# Altium schematic sheet Decawave.schdoc(Decawave)
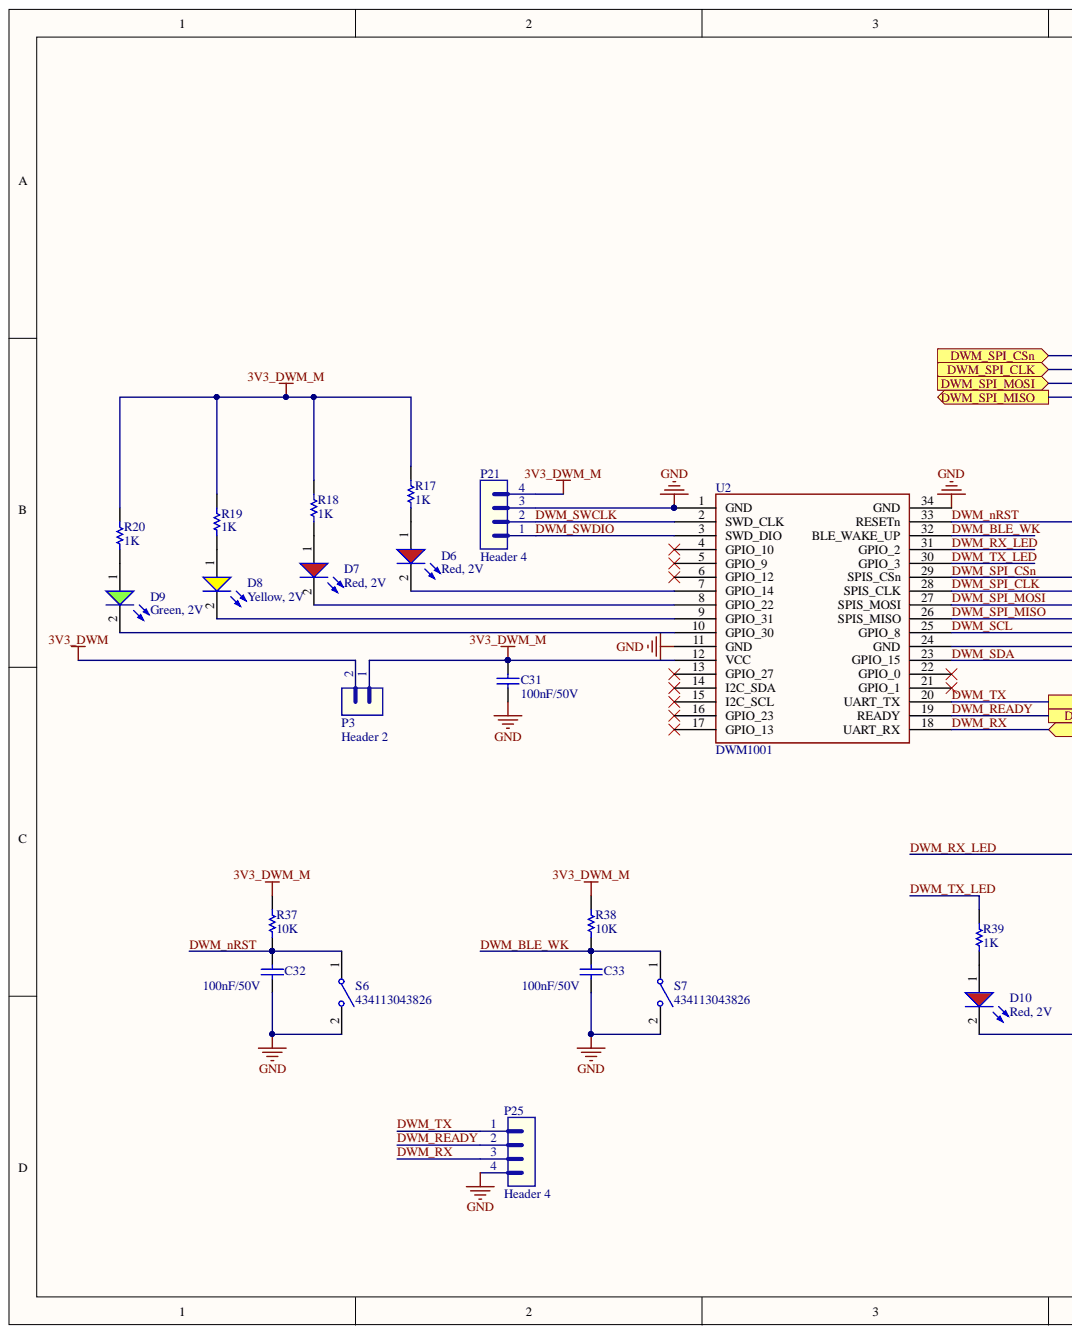
[diagram p0: Decawave.schdoc, left]
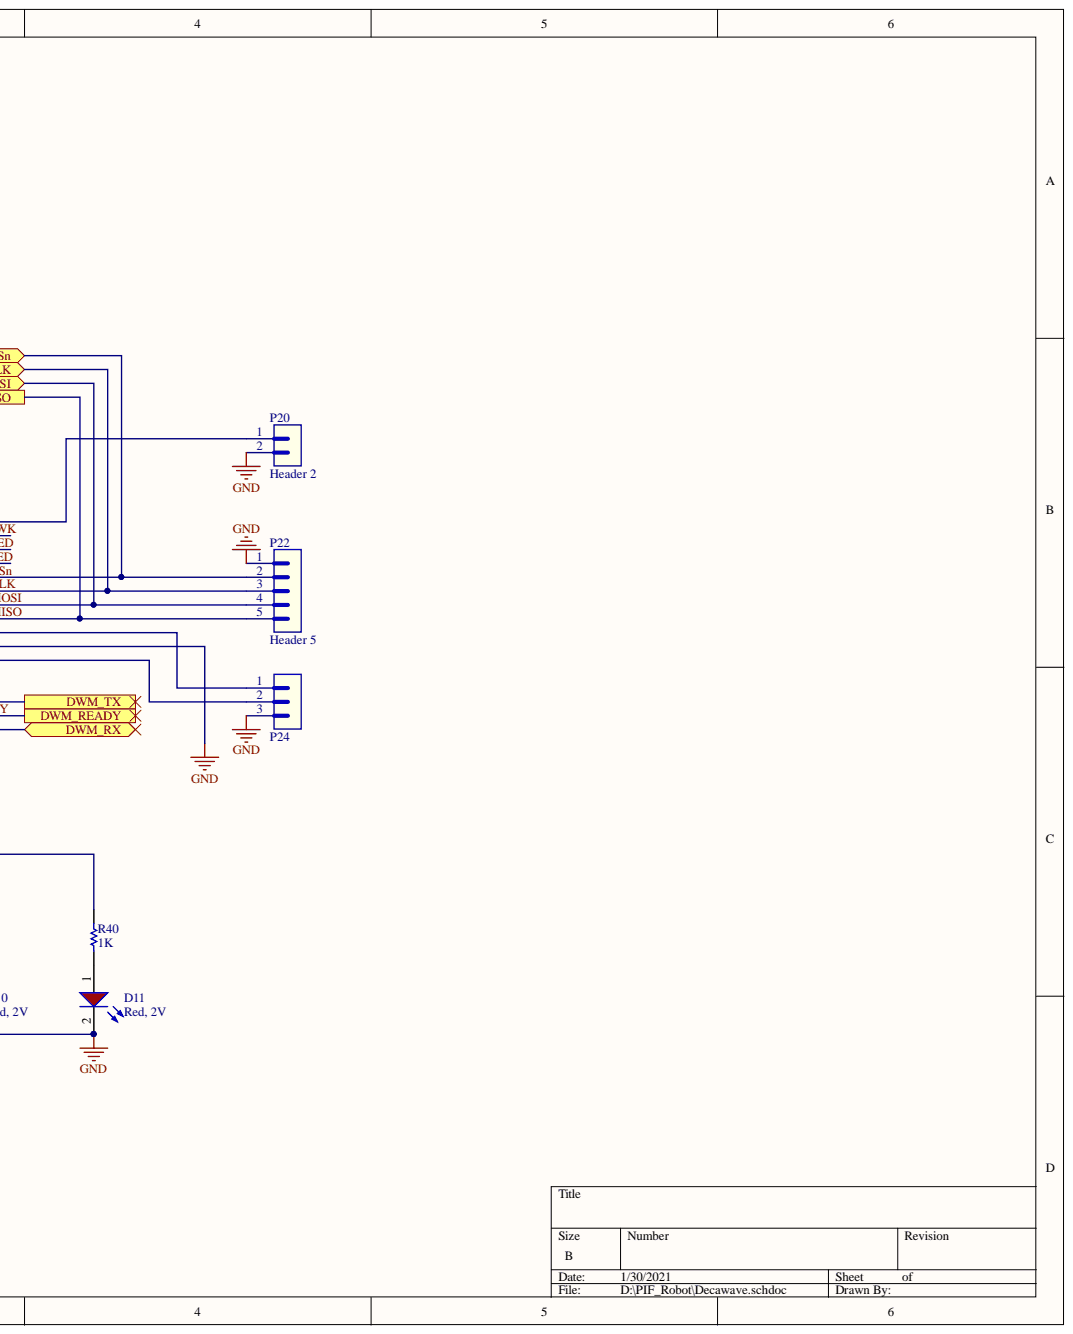
[diagram p1: Decawave.schdoc, right]

## Parts
C31  value 100nF/50V  [CAP-SM-0805]  (hidden comment: Cap-100nF-50V-0805)
C32  value 100nF/50V  [CAP-SM-0805]  (hidden comment: Cap-100nF-50V-0805)
C33  value 100nF/50V  [CAP-SM-0805]  (hidden comment: Cap-100nF-50V-0805)
D6  value Red, 2V  [LED_0805_Blue]  (hidden comment: LED-RED-TH-3mm)
D7  value Red, 2V  [LED_0805_Blue]  (hidden comment: LED-RED-TH-3mm)
D8  value Yellow, 2V  [LED_0805_Blue]  (hidden comment: LED-YELLOW-TH-3mm)
D9  value Green, 2V  [LED_0805_Blue]  (hidden comment: LED-GREEN-TH-3mm)
D10  value Red, 2V  [LED_0805_Blue]  (hidden comment: LED-RED-TH-3mm)
D11  value Red, 2V  [LED_0805_Blue]  (hidden comment: LED-RED-TH-3mm)
P3  Header 2  [JP2]
P20  Header 2  [JP2]
P21  Header 4  [JP4]
P22  Header 5  [JP5]
P24  Header 3  [JP3]
P25  Header 4  [JP4]
R17  value 1K  [RES-SM-0805]  (hidden comment: Res-1K-0805)
R18  value 1K  [RES-SM-0805]  (hidden comment: Res-1K-0805)
R19  value 1K  [RES-SM-0805]  (hidden comment: Res-1K-0805)
R20  value 1K  [RES-SM-0805]  (hidden comment: Res-1K-0805)
R37  value 10K  [RES-SM-0805]  (hidden comment: Res-10k-0805)
R38  value 10K  [RES-SM-0805]  (hidden comment: Res-10k-0805)
R39  value 1K  [RES-SM-0805]  (hidden comment: Res-1K-0805)
R40  value 1K  [RES-SM-0805]  (hidden comment: Res-1K-0805)
S6  434113043826  [434113043826]
S7  434113043826  [434113043826]
U2  DWM1001  [DWM1001]

## Ports
DWM_READY, DWM_RX, DWM_SPI_CLK, DWM_SPI_CSn, DWM_SPI_MISO, DWM_SPI_MOSI, DWM_TX

## Nets
3V3_DWM: P3.2
3V3_DWM_M: C31.2 P3.1 P21.4 R17.2 R18.2 R19.2 R20.2 R37.2 R38.2 U2.12(VCC)
GND: C31.1 C32.2 C33.2 D10.2 D11.2 P20.2 P21.3 P22.1 P24.3 P25.4 S6.2 S7.2 U2.1(GND) U2.11(GND) U2.24(GND) U2.34(GND)
DWM_BLE_WK: C33.1 R38.1 S7.1 U2.32(BLE_WAKE_UP)
DWM_nRST: C32.1 P20.1 R37.1 S6.1 U2.33(RESETn)
DWM_READY: P25.2 U2.19(READY) ; port DWM_READY
DWM_RX: P25.3 U2.18(UART_RX) ; port DWM_RX
DWM_RX_LED: R40.2 U2.31(GPIO_2)
DWM_SCL: P24.1 U2.25(GPIO_8)
DWM_SDA: P24.2 U2.23(GPIO_15)
DWM_SPI_CLK: P22.3 U2.28(SPIS_CLK) ; port DWM_SPI_CLK
DWM_SPI_CSn: P22.2 U2.29(SPIS_CSn) ; port DWM_SPI_CSn
DWM_SPI_MISO: P22.5 U2.26(SPIS_MISO) ; port DWM_SPI_MISO
DWM_SPI_MOSI: P22.4 U2.27(SPIS_MOSI) ; port DWM_SPI_MOSI
DWM_SWCLK: P21.2 U2.2(SWD_CLK)
DWM_SWDIO: P21.1 U2.3(SWD_DIO)
DWM_TX: P25.1 U2.20(UART_TX) ; port DWM_TX
DWM_TX_LED: R39.2 U2.30(GPIO_3)
NetD6_1: D6.1 R17.1
NetD6_2: D6.2 U2.7(GPIO_14)
NetD7_1: D7.1 R18.1
NetD7_2: D7.2 U2.8(GPIO_22)
NetD8_1: D8.1 R19.1
NetD8_2: D8.2 U2.9(GPIO_31)
NetD9_1: D9.1 R20.1
NetD9_2: D9.2 U2.10(GPIO_30)
NetD10_1: D10.1 R39.1
NetD11_1: D11.1 R40.1

Not connected: U2 4(GPIO_10) 5(GPIO_9) 6(GPIO_12) 13(GPIO_27) 14(I2C_SDA) 15(I2C_SCL) 16(GPIO_23) 17(GPIO_13) 21(GPIO_1) 22(GPIO_0)

## Library data of the parts
C31-C33 = Cap-100nF-50V-0805: CAP CER 0.1UF 50V Y5V 0805; Manufacturer Part Number: CL21F104ZBCNNNC; Manufacturer: Samsung Electro-Mechanics; Supplier 1 Part Number: 1276-1007-1-ND; Supplier 1 Link: https://www.digikey.com/product-detail/en/samsung-electro-mechanics/CL21F104ZBCNNNC/1276-1007-1-ND/3889093; Supplier 1: Digikey; Local Supplier Link: https://thegioiic.com/products/tu-100nf-0-1uf-50v-0805; Local Supplier: thegioiic; Library Name: Capacitor.SchLib; Component Kind: Standard
D6 D7 D10 D11 = LED-RED-TH-3mm: LED RED CLEAR T-1 T/H; Manufacturer Part Number: WP710A10SEC/J3; Manufacturer: Kingbright; Supplier 1 Part Number: 754-1589-ND; Supplier 1 Link: https://www.digikey.com/product-detail/en/kingbright/WP710A10SEC-J3/754-1589-ND/2769815; Supplier 1: Digikey; Local Supplier Link: https://thegioiic.com/products/led-do-3mm-chan-dai; Local Supplier: thegioiic; Library Name: LED.SchLib; Component Kind: Standard
D9 = LED-GREEN-TH-3mm: LED GREEN CLEAR T-1 T/H; Manufacturer Part Number: WP710A10ZGC/G; Manufacturer: Kingbright; Value: Green, 2V; Supplier 1 Part Number: 754-1612-ND; Supplier 1 Link: https://www.digikey.com/product-detail/en/kingbright/WP710A10ZGC-G/754-1612-ND/2769684; Supplier 1: Digikey; Local Supplier Link: https://thegioiic.com/products/led-xanh-la-3mm-chan-dai; Local Supplier: thegioiic; Library Name: LED.SchLib; Component Kind: Standard
P3 P20 = Header 2: Header, 2-Pin; Library Name: Header-connector.SchLib; LatestRevisionNote: Re-released for DXP Platform.; LatestRevisionDate: 17-Jul-2002; Component Kind: Standard; Publisher: Altium Limited
P21 P25 = Header 4: Header, 4-Pin; Library Name: Header-connector.SchLib; LatestRevisionNote: Re-released for DXP Platform.; LatestRevisionDate: 17-Jul-2002; Component Kind: Standard; Publisher: Altium Limited
P22 = Header 5: Header, 5-Pin; Library Name: Header-connector.SchLib; LatestRevisionNote: Re-released for DXP Platform.; LatestRevisionDate: 17-Jul-2002; Component Kind: Standard; Publisher: Altium Limited
P24 = Header 3: Header, 3-Pin; Library Name: Header-connector.SchLib; LatestRevisionNote: Re-released for DXP Platform.; LatestRevisionDate: 17-Jul-2002; Component Kind: Standard; Publisher: Altium Limited
R17-R20 R39 R40 = Res-1K-0805: RES 1K OHM 1% 1/4W 0805; Manufacturer Part Number: RNCP0805FTD1K00; Manufacturer: Stackpole Electronics Inc; Supplier 1 Part Number: RNCP0805FTD1K00CT-ND; Supplier 1 Link: https://www.digikey.com/product-detail/en/stackpole-electronics-inc/RNCP0805FTD1K00/RNCP0805FTD1K00CT-ND/2240568; Supplier 1: Digikey; Local Supplier Link: https://thegioiic.com/products/dien-tro-1k-ohm-0805-5; Local Supplier: thegioiic; Library Name: Resistor.SchLib; Component Kind: Standard
R37 R38 = Res-10k-0805: RES 10K OHM 1% 1/4W 0805; Manufacturer Part Number: RNCP0805FTD10K0; Manufacturer: Stackpole Electronics Inc; Supplier 1 Part Number: RNCP0805FTD10K0CT-ND; Supplier 1 Link: https://www.digikey.com/product-detail/en/stackpole-electronics-inc/RNCP0805FTD10K0/RNCP0805FTD10K0CT-ND/2240601; Supplier 1: Digikey; Local Supplier Link: https://thegioiic.com/products/dien-tro-10k-ohm-0805-5; Local Supplier: thegioiic; Library Name: Resistor.SchLib; Component Kind: Standard
S6 S7 = 434113043826: WS-TASV J-Bend SMT Tact Switch 6x3.5mm, height 4.3mm, 260gf; Washable: No; Rated Voltage: 12VDC; Rated Current: 50mA; Terminal Plating: Silver; Terminal Material: Copper Alloy; Published Date: September 2019; Part Number: 434113043826; Packaging: Tape and Reel; Operation Force: 260gf; Operating Temperature: -40 to +85degC; Mounting Technology: SMT; Library Name: 434113043826_Altium_WS-TASV_rev20a.IntLib; Height: 4.3mm; Contact Plating: Silver; Contact Material: Stainless Steel; ComponentLink2URL: https://katalog.we-online.com/em/datasheet/434113043826.pdf; ComponentLink2Description: Datasheet; ComponentLink1URL: http://www.we-online.de; ComponentLink1Description: Manufacturer; Component Kind: Standard; Publisher: Wurth Elektronik
D8 = LED-YELLOW-TH-3mm: Library Name: LED.SchLib; Component Kind: Standard
U2 = DWM1001: Library Name: PIF_robot.SchLib; Component Kind: Standard
